# Altium schematic sheet Flash.SchDoc
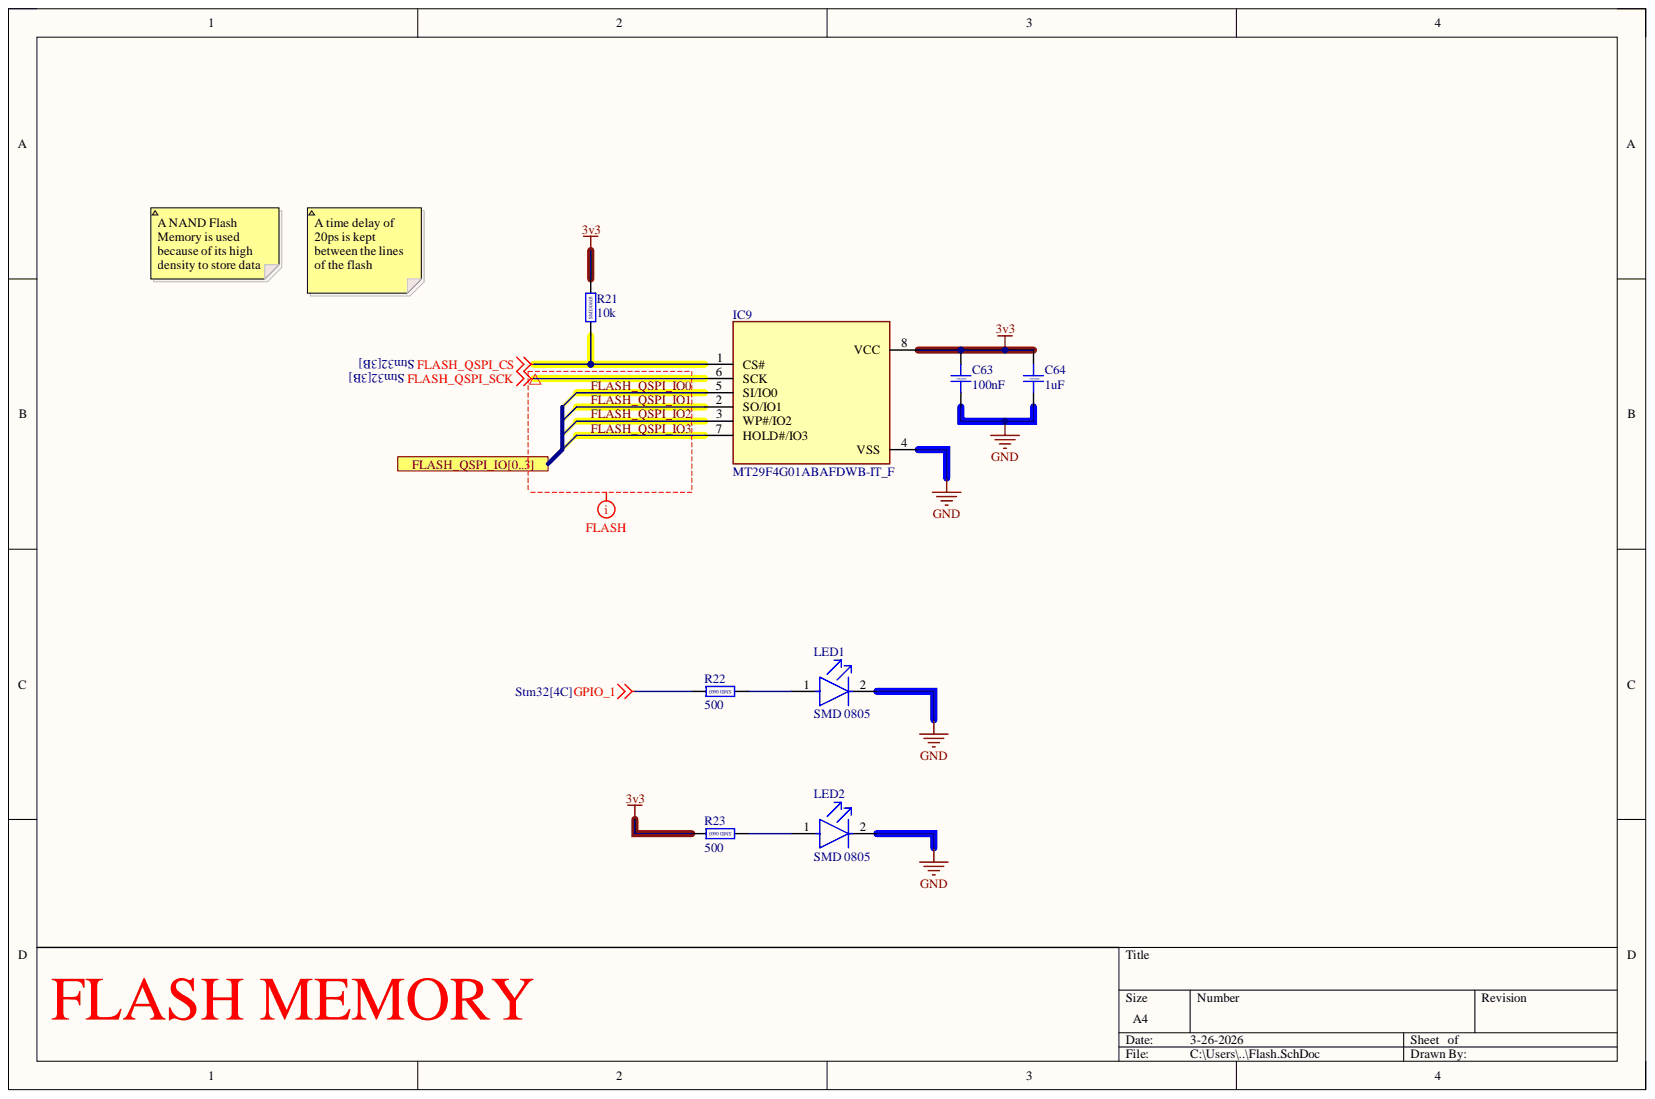
[diagram p0: Flash.SchDoc]

## Parts
C63  100nF  [CAP_0603]
C64  1uF  [CAP_0603]
IC9  MT29F4G01ABAFDWB-IT_F  [SON127P800X600X65-8N]
LED1  SMD 0805  Case Code (Imperial): 0805  [FP-SM0805YCL-MFG]
LED2  SMD 0805  Case Code (Imperial): 0805  [FP-SM0805YCL-MFG]
R21  10k  [RES_0603]
R22  500  [RES_0603]
R23  500  [RES_0603]

## Ports
FLASH_QSPI_IO0, FLASH_QSPI_IO1, FLASH_QSPI_IO2, FLASH_QSPI_IO3, FLASH_QSPI_IO[0..3]

## Nets
3v3: C63.2 C64.2 IC9.8(VCC) R21.2 R23.2
FLASH_QSPI_CS: IC9.1(CS#) R21.1
FLASH_QSPI_SCK: IC9.6(SCK)
GND: C63.1 C64.1 IC9.4(VSS) LED1.2 LED2.2
GPIO_1: R22.2
FLASH_QSPI_IO0: IC9.5(SI/IO0) ; port FLASH_QSPI_IO[0..3]  [also labelled ]
FLASH_QSPI_IO1: IC9.2(SO/IO1) ; port FLASH_QSPI_IO[0..3]  [also labelled ]
FLASH_QSPI_IO2: IC9.3(WP#/IO2) ; port FLASH_QSPI_IO[0..3]  [also labelled ]
FLASH_QSPI_IO3: IC9.7(HOLD#/IO3) ; port FLASH_QSPI_IO[0..3]  [also labelled ]
NetLED1_1: LED1.1 R22.1
NetLED2_1: LED2.1 R23.1

## Library data of the parts
C63 C64 = Capacitor-SMD-0603: Capacitor SMD 0603 RATED; Component Kind: Standard; Library Name: STeRG-Passives-Standard.SchLib
IC9 = MT29F4G01ABAFDWB-IT_F: Integrated Circuit; Manufacturer_Name: Micron; Manufacturer_Part_Number: MT29F4G01ABAFDWB-IT:F; Arrow Part Number: MT29F4G01ABAFDWB-IT:F; Arrow Price/Stock: null?region=nac; Component Kind: Standard; Datasheet Link: https://www.farnell.com/datasheets/3151403.pdf; Height: 0.65mm; Library Name: SamacSys.SchLib; Mouser Part Number: 340-359563; Mouser Price/Stock: https://www.mouser.co.uk/ProductDetail/Micron/MT29F4G01ABAFDWB-ITF?qs=wUXugUrL1qwrjb7To7JPbA%3D%3D
LED1 LED2 = CMP-35283-000007-1: LED YELLOW CLEAR 0805 SMD; Dominant Wavelength: 592nm; Forward Current: 2mA; Forward Voltage: 1.9V; Lens Color: Colorless; Luminous Intensity: 11.5mcd; Viewing Angle: 130°; Case Code (Metric): 2012; Case/Package: 0805; Color: Yellow; Component Kind: Standard; Height: 0.8mm; Illumination Color: Yellow; Lead Free: Lead Free; Length: 2mm; Lens Transparency: Clear; Library Name: Altium Content Vault; Max Operating Temperature: 80°C; Min Operating Temperature: -30°C; Mount: Surface Mount; Package Quantity: 4000; Packaging: Tape and Reel; Test Current: 2mA; Width: 1.2mm
R21-R23 = Resistor-SMD-0603: Resistor SMD 0603 RATED; Component Kind: Standard; Library Name: STeRG-Passives-Standard.SchLib
